# Altium schematic sheet LED_Bar.SchDoc(LED_Bar)
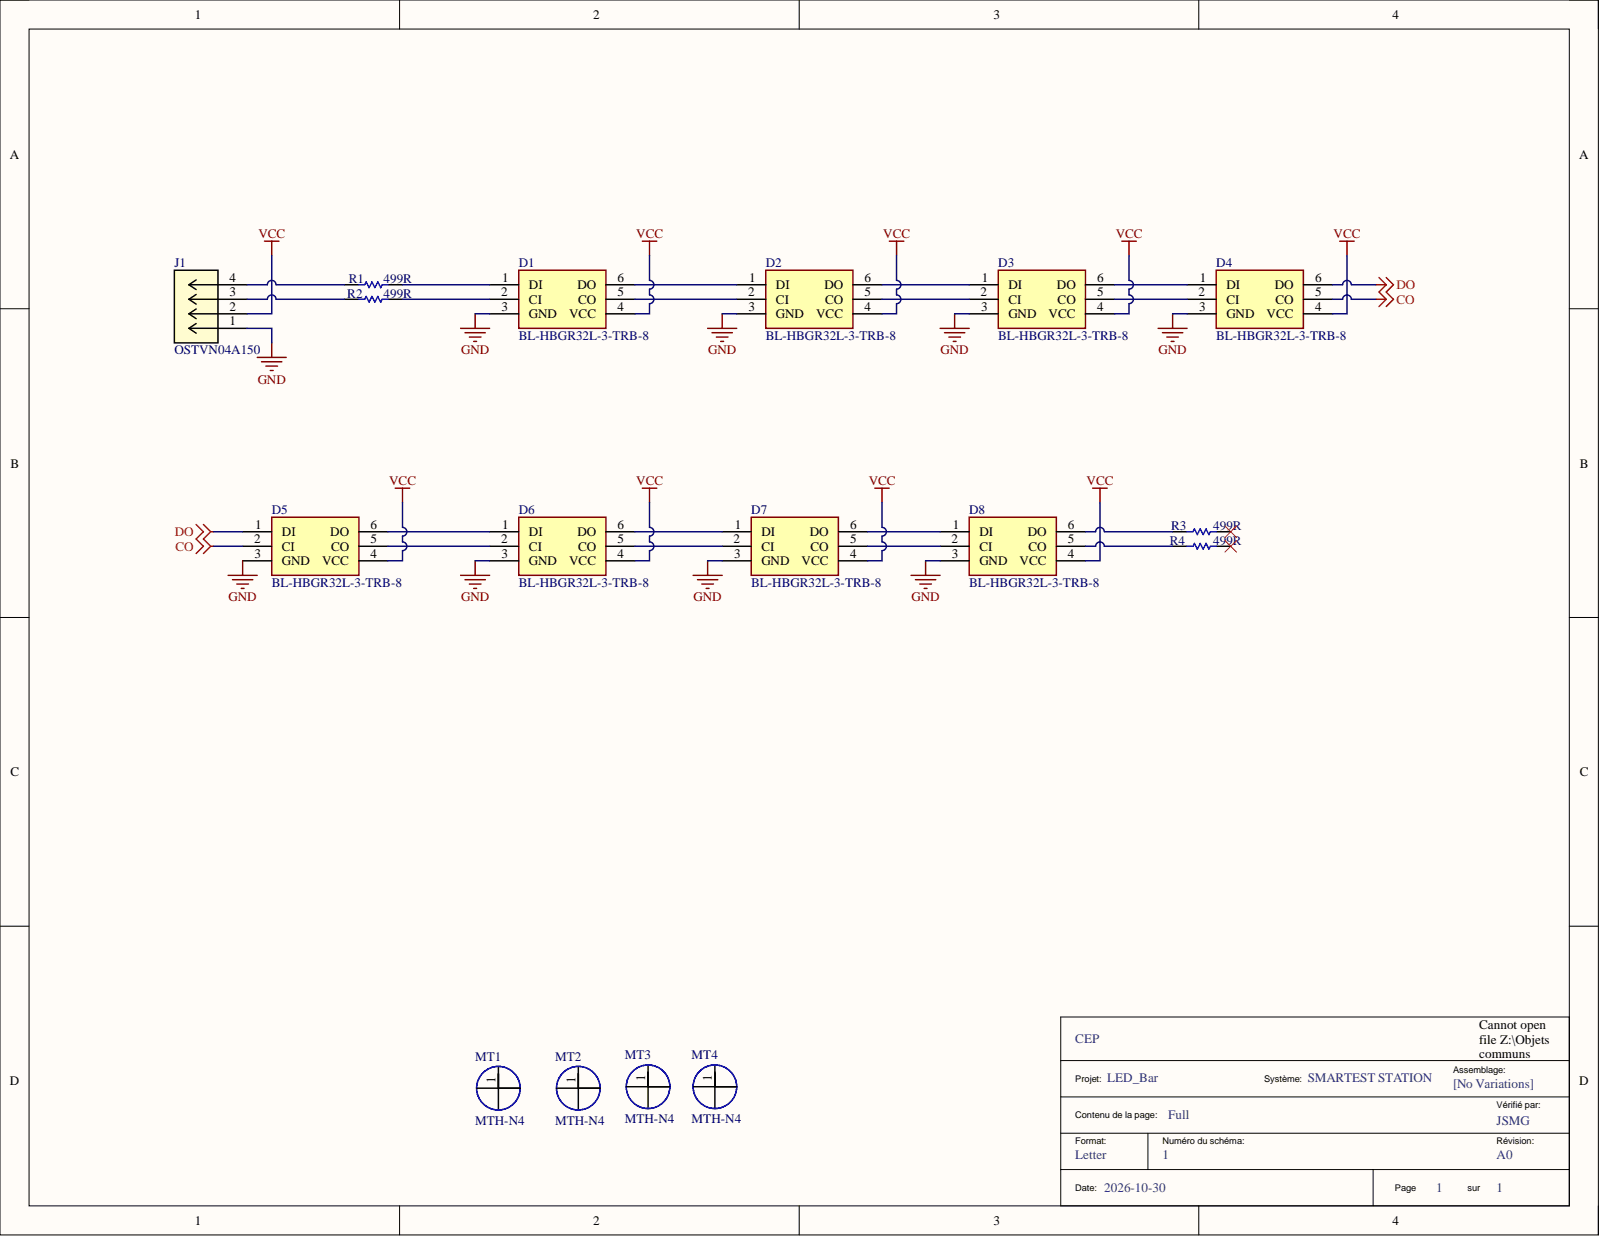
[diagram p0: LED_Bar.SchDoc]

## Parts
D1  BL-HBGR32L-3-TRB-8  [BLHBGR32L3TRB8]
D2  BL-HBGR32L-3-TRB-8  [BLHBGR32L3TRB8]
D3  BL-HBGR32L-3-TRB-8  [BLHBGR32L3TRB8]
D4  BL-HBGR32L-3-TRB-8  [BLHBGR32L3TRB8]
D5  BL-HBGR32L-3-TRB-8  [BLHBGR32L3TRB8]
D6  BL-HBGR32L-3-TRB-8  [BLHBGR32L3TRB8]
D7  BL-HBGR32L-3-TRB-8  [BLHBGR32L3TRB8]
D8  BL-HBGR32L-3-TRB-8  [BLHBGR32L3TRB8]
J1  OSTVN04A150  [CONN_OSTVN04A150_OST]
MT1  MTH-N4  [MTH-N4]
MT2  MTH-N4  [MTH-N4]
MT3  MTH-N4  [MTH-N4]
MT4  MTH-N4  [MTH-N4]
R1  value 499R  [R0603]  (hidden comment: RT0603BRD07499RL)
R2  value 499R  [R0603]  (hidden comment: RT0603BRD07499RL)
R3  value 499R  [R0603]  (hidden comment: RT0603BRD07499RL)
R4  value 499R  [R0603]  (hidden comment: RT0603BRD07499RL)

## Nets
CO: D4.5(CO) D5.2(CI)
DO: D4.6(DO) D5.1(DI)
GND: D1.3(GND) D2.3(GND) D3.3(GND) D4.3(GND) D5.3(GND) D6.3(GND) D7.3(GND) D8.3(GND) J1.1
VCC: D1.4(VCC) D2.4(VCC) D3.4(VCC) D4.4(VCC) D5.4(VCC) D6.4(VCC) D7.4(VCC) D8.4(VCC) J1.2
NetD1_1: D1.1(DI) R1.2
NetD1_2: D1.2(CI) R2.2
NetD1_5: D1.5(CO) D2.2(CI)
NetD1_6: D1.6(DO) D2.1(DI)
NetD2_5: D2.5(CO) D3.2(CI)
NetD2_6: D2.6(DO) D3.1(DI)
NetD3_5: D3.5(CO) D4.2(CI)
NetD3_6: D3.6(DO) D4.1(DI)
NetD5_5: D5.5(CO) D6.2(CI)
NetD5_6: D5.6(DO) D6.1(DI)
NetD6_5: D6.5(CO) D7.2(CI)
NetD6_6: D6.6(DO) D7.1(DI)
NetD7_5: D7.5(CO) D8.2(CI)
NetD7_6: D7.6(DO) D8.1(DI)
NetD8_5: D8.5(CO) R4.1
NetD8_6: D8.6(DO) R3.1
NetJ1_3: J1.3 R2.1
NetJ1_4: J1.4 R1.1

Not connected: MT1 1; MT2 1; MT3 1; MT4 1; R3 2; R4 2

## Library data of the parts
D1-D8 = DIO0019: ADDRESS LED DISCRETE SERIAL RGB; Manufacturer: ABOC; ID_CEP: MDIO0019; Verif_1: JSMG; Component Kind: Standard; Library Name: CEP SYMBOLS.SchLib; PN_Manuf: BL-HBGR32L-3-TRB-8
J1 = OSTVN04A150: TERM BLK 4P SIDE ENT 2.54MM PCB; Manufacturer: On Shore Technology Inc.; Manufacturer_Name: On Shore Technology; Component Kind: Standard; Fitted: Fitted; Library Name: Schlib1.SchLib; Manufacturer_Part_Number: OSTVN04A150; PN_Manuf: OSTVN04A150; RefDes: RefDes; Type: Type; Copyright: Copyright (C) 2025 Ultra Librarian. All rights reserved.
MT1-MT4 = DIV0013: Monting Hole for Imperial n°4; Manufacturer: NA; ID_CEP: MDIV0013; Verif_1: JSMG; Component Kind: Standard; Library Name: CEP SYMBOLS.SchLib; PN_Manuf: NA
R1-R4 = RES0015: RES SMD 499 OHM 0.1% 1/10W 0603; Manufacturer: YAGEO; ID_CEP: MRES0015; Verif_1: JSMG; Component Kind: Standard; Cost: X; LatestRevisionDate: 17-Jul-2002; LatestRevisionNote: Re-released for DXP Platform.; Library Name: CEP SYMBOLS.SchLib; Package: 0603; PackageDescription: Axial Device, Thru-Hole; 2 Leads; 0.3 in Pin Spacing; PackageReference: AXIAL-0.3; PN_Manuf: RT0603BRD07499RL; Published: 8-Jun-2000; Publisher: Altium Limited
